# Altium schematic sheet floppy interface 2.SchDoc
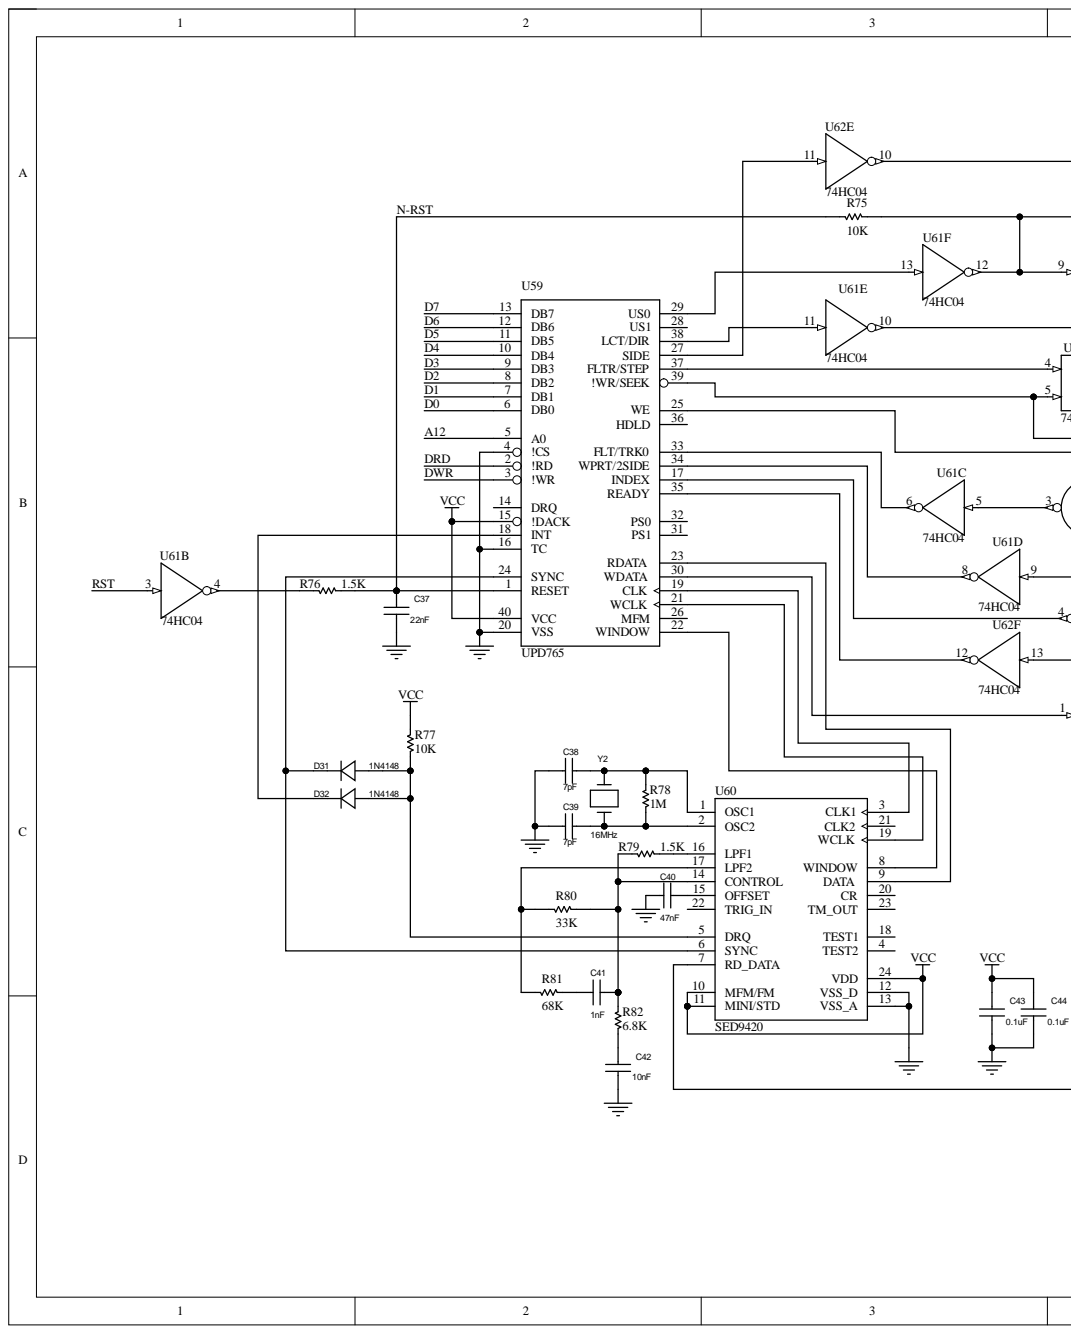
[diagram p0: floppy interface 2.SchDoc, left]
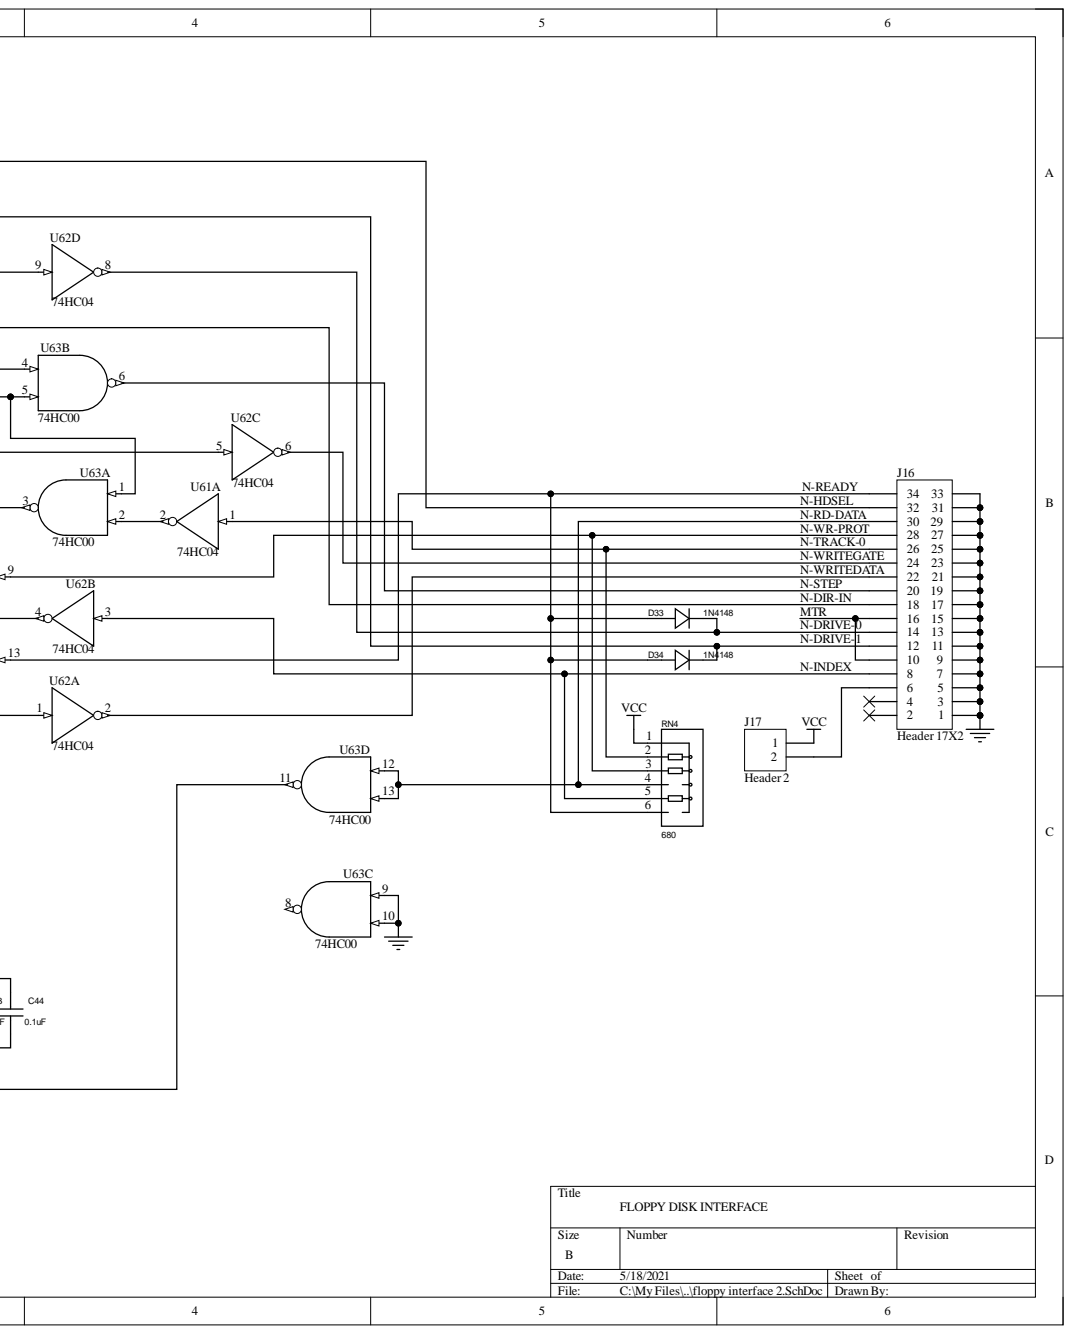
[diagram p1: floppy interface 2.SchDoc, right]

## Parts
C37  22nF  [RAD/.200X.100/LS.100/.031]
C38  7pF  [RAD/.200X.100/LS.100/.031]
C39  7pF  [RAD/.200X.100/LS.100/.031]
C40  47nF  [RAD/.200X.100/LS.100/.031]
C41  1nF  [RAD/.200X.100/LS.100/.031]
C42  10nF  [RAD/.200X.100/LS.100/.031]
C43  0.1uF  [RAD/.200X.100/LS.100/.031]
C44  0.1uF  [RAD/.250X.100/LS.150/.031]
D31  1N4148  [DAX2/.300X.050/.028]
D32  1N4148  [DAX2/.300X.050/.028]
D33  1N4148  [DAX2/.300X.050/.028]
D34  1N4148  [DAX2/.300X.050/.028]
J16  Header 17X2  [HDR2X17]
J17  Header 2  [HDR1X2]
R75  10K  [AX/.350X.100/.034]
R76  1.5K  [AX/.350X.100/.034]
R77  10K  [AX/.350X.100/.034]
R78  1M  [AX/.350X.100/.034]
R79  1.5K  [AX/.350X.100/.034]
R80  33K  [AX/.350X.100/.034]
R81  68K  [AX/.350X.100/.034]
R82  6.8K  [AX/.350X.100/.034]
RN4  680  [SIP/TM/L.600/6]
U59  UPD765  [DIP.100/40/W.600/L2.025]
U60  SED9420  [DIP.100/24/W.600/L1.200]
U61  74HC04  [SOIC127P600-14AN]  (parts A,B,C,D,E,F)
U62  74HC04  [SOIC127P600-14AN]  (parts A,B,C,D,E,F)
U63  74HC00  [SOIC127P600-14AN]  (parts A,B,C,D)
Y2  16MHz  [RAD/.300X.125/LS.200/.031]

## Nets
GND: C37.2 C38.1 C39.1 C40.2 C42.2 C43.2 C44.2 J16.1 J16.3 J16.5 J16.7 J16.9 J16.11 J16.13 J16.15 J16.17 J16.19 J16.21 J16.23 J16.25 J16.27 J16.29 J16.31 J16.33 U59.4(!CS) U59.16(TC) U59.20(VSS) U60.12(VSS_D) U60.13(VSS_A) U61.7 U62.7 U63.7 U63.9 U63.10
VCC: C43.1 C44.1 J17.1 R77.2 RN4.1 U59.15(!DACK) U59.40(VCC) U60.10(MFM/FM) U60.11(MINI/STD) U60.24(VDD) U61.14 U62.14 U63.14
A12: U59.5(A0)
D0: U59.6(DB0)
D1: U59.7(DB1)
D2: U59.8(DB2)
D3: U59.9(DB3)
D4: U59.10(DB4)
D5: U59.11(DB5)
D6: U59.12(DB6)
D7: U59.13(DB7)
DRD: U59.2(!RD)
DWR: U59.3(!WR)
MTR: J16.10 J16.16
N-DIR-IN: J16.18 U61.10
N-DRIVE-0: D33.2 J16.14 U62.8
N-DRIVE-1: D34.2 J16.12 R75.1 U61.12 U62.9
N-HDSEL: J16.32 U62.10
N-INDEX: J16.8 RN4.5 U62.3
N-RD-DATA: J16.30 RN4.4 U63.12 U63.13
N-READY: D33.1 D34.1 J16.34 RN4.6 U62.13
N-RST: C37.1 R75.2 R76.1 U59.1(RESET)
N-STEP: J16.20 U63.6
N-TRACK-0: J16.26 RN4.2 U61.1
N-WR-PROT: J16.28 RN4.3 U61.9
N-WRITEDATA: J16.22 U62.2
N-WRITEGATE: J16.24 U62.6
RST: U61.3
NetC38_2: C38.2 R78.1 U60.1(OSC1) Y2.2
NetC39_2: C39.2 R78.2 U60.2(OSC2) Y2.1
NetC40_1: C40.1 U60.15(OFFSET)
NetC41_1: C41.1 R81.2
NetC41_2: C41.2 R79.2 R80.1 R82.1 U60.14(CONTROL)
NetC42_1: C42.1 R82.2
NetD31_1: D31.1 D32.1 R77.1 U60.5(DRQ)
NetD31_2: D31.2 U59.24(SYNC) U60.6(SYNC)
NetD32_2: D32.2 U59.18(INT)
NetJ16_6: J16.6 J17.2
NetR76_2: R76.2 U61.4
NetR79_1: R79.1 U60.16(LPF1)
NetR80_2: R80.2 R81.1 U60.17(LPF2)
NetU59_17: U59.17(INDEX) U62.4
NetU59_19: U59.19(CLK) U60.3(CLK1)
NetU59_21: U59.21(WCLK) U60.19(WCLK)
NetU59_22: U59.22(WINDOW) U60.8(WINDOW)
NetU59_23: U59.23(RDATA) U60.9(DATA)
NetU59_25: U59.25(WE) U62.5
NetU59_27: U59.27(SIDE) U62.11
NetU59_29: U59.29(US0) U61.13
NetU59_30: U59.30(WDATA) U62.1
NetU59_33: U59.33(FLT/TRK0) U61.6
NetU59_34: U59.34(WPRT/2SIDE) U61.8
NetU59_35: U59.35(READY) U62.12
NetU59_37: U59.37(FLTR/STEP) U63.4
NetU59_38: U59.38(LCT/DIR) U61.11
NetU59_39: U59.39(!WR/SEEK) U63.1 U63.5
NetU60_7: U60.7(RD_DATA) U63.11
NetU61_2: U61.2 U63.2
NetU61_5: U61.5 U63.3

Not connected: J16 2 4; U59 14(DRQ) 26(MFM) 28(US1) 31(PS1) 32(PS0) 36(HDLD); U60 4(TEST2) 18(TEST1) 20(CR) 21(CLK2) 22(TRIG_IN) 23(TM_OUT); U63 8

## Library data of the parts
C37-C44 = CAP NP: COMPFIXED: NO; COMPGROUP: 0; COMPHEIGHT: 0.000; COMPLOC: [6275.000,975.000]; COMPSIDE: TOP; FPLIST: RAD/.150X.100/LS.100/.031; COMPKEY: NO; COMPLOCKED: NO; Component Kind: Standard; COMPROT: 0; DECOUPLER: NO; MEMORY: NO
D31-D34 = 1N4148: COMPFIXED: NO; COMPGROUP: 0; COMPHEIGHT: 0.000; COMPLOC: [7450.000,2300.000]; COMPROT: 90; COMPSIDE: TOP; COMPKEY: NO; COMPLOCKED: NO; Component Kind: Standard; DECOUPLER: NO; FPLIST: DAX2/.300X.050/.028; MEMORY: NO
J16 = Header 17X2: Header, 17-Pin, Dual row; Component Kind: Standard; LatestRevisionDate: 17-Jul-2002; LatestRevisionNote: Re-released for DXP Platform.; Library Name: Miscellaneous Connectors.IntLib; Signal Integrity: Connector; Publisher: Altium Limited
J17 = Header 2: Header, 2-Pin; Component Kind: Standard; LatestRevisionDate: 17-Jul-2002; LatestRevisionNote: Re-released for DXP Platform.; Library Name: Miscellaneous Connectors.IntLib; Signal Integrity: Connector; Publisher: Altium Limited
R75-R82 = Res1: Resistor; Component Kind: Standard; LatestRevisionDate: 17-Jul-2002; LatestRevisionNote: Re-released for DXP Platform.; Library Name: Miscellaneous Devices.IntLib; PackageReference: AXIAL-0.3; Published: 8-Jun-2000; Signal Integrity: Res; Simulation: RESISTOR; Publisher: Altium Limited
  R75 R77: Value: 10K
  R76 R79: Value: 1.5K
  R78: Value: 1M
  R80: Value: 33K
  R81: Value: 68K
  R82: Value: 6.8K
RN4 = RESNW_BU_5/SIP: COMPFIXED: NO; COMPGROUP: 0; COMPHEIGHT: 0.000; COMPLOC: [550.000,2350.000]; COMPROT: 270; COMPSIDE: TOP; FPLIST: SIP/TM/L.900/9; COMPKEY: NO; COMPLOCKED: NO; Component Kind: Standard; DECOUPLER: NO; MEMORY: NO; Library Name: floppy_interface.SchLib
U61 U62 = 74HC04: IO_LEVEL: 0; PSpiceTemplate: X^@REFDES %A %Y %VCC %GND @MODEL PARAMS:\n+ IO_LEVEL=@IO_LEVEL MNTYMXDLY=@MNTYMXDLY; Component Kind: Standard; MNTYMXDLY: 0; Simulation: 74HC04; Library Name: floppy_interface.SchLib
U63 = 74HC00: IO_LEVEL: 0; PSpiceTemplate: X^@REFDES %A %B %Y %VCC %GND @MODEL PARAMS:\n+ IO_LEVEL=@IO_LEVEL MNTYMXDLY=@MNTYMXDLY; Component Kind: Standard; MNTYMXDLY: 0; Simulation: 74HC00; Library Name: floppy_interface.SchLib
Y2 = CRYSTAL: COMPFIXED: NO; COMPGROUP: 0; COMPHEIGHT: 0.000; COMPLOC: [6575.317,975.317]; COMPSIDE: TOP; COMPKEY: NO; COMPLOCKED: NO; Component Kind: Standard; COMPROT: 0; DECOUPLER: NO; MEMORY: NO
U59 = UPD765: Component Kind: Standard; Library Name: 8Bit_Parts
U60 = SED9420: Component Kind: Standard; Library Name: 8Bit_Parts (2)
